# Altium schematic sheet 14871_Schematic.SchDoc(14871_Schematic) (continued)

## Library data of the parts
C1 C6 C7 C9 C10 = 08055C104KAT2A: CAP CER 0.1UF X7R 50V 0805  +/-10%; 50WVDC; SMD; 2.5% Df; 0.037IN. ,; Manufacturer: Kyocera AVX; Capacitance: 100nF; Dielectric Material: Ceramic; Insulation Resistance: 100GR; Tolerance: 10%; Case Code (Metric): 2012; Case/Package: 0805; Category: Ceramic Capacitors; Component Kind: Standard; ComponentLink1Description: https://datasheet.ciiva.com/19475/2009691-19475858.pdf?src-supplier=Jameco; ComponentLink1URL: https://datasheet.ciiva.com/19475/2009691-19475858.pdf?src-supplier=Jameco; ComponentLink2Description: https://datasheet.ciiva.com/11786/0900766b802d4698-11786116.pdf?src-supplier=RSComponents; ComponentLink2URL: https://datasheet.ciiva.com/11786/0900766b802d4698-11786116.pdf?src-supplier=RSComponents; ComponentLink3Description: https://datasheet.ciiva.com/2988/cx7r-2988014.pdf?src-supplier=Avnet; ComponentLink3URL: https://datasheet.ciiva.com/2988/cx7r-2988014.pdf?src-supplier=Avnet; ComponentLink4Description: https://datasheet.ciiva.com/16199/cx7r-16199014.pdf?src-supplier=Future+Electronics; ComponentLink4URL: https://datasheet.ciiva.com/16199/cx7r-16199014.pdf?src-supplier=Future+Electronics; ComponentLink5Description: https://datasheet.ciiva.com/11504/cx7r-11504044.pdf?src-supplier=Avnet; ComponentLink5URL: https://datasheet.ciiva.com/11504/cx7r-11504044.pdf?src-supplier=Avnet; ComponentLink6Description: https://datasheet.ciiva.com/150/cx7r-150258.pdf?src-supplier=Digi-Key; ComponentLink6URL: https://datasheet.ciiva.com/150/cx7r-150258.pdf?src-supplier=Digi-Key; ComponentLink7Description: https://datasheet.ciiva.com/150/cx7r-150257.pdf?src-supplier=Digi-Key; ComponentLink7URL: https://datasheet.ciiva.com/150/cx7r-150257.pdf?src-supplier=Digi-Key; ComponentLink8Description: https://datasheet.ciiva.com/150/cx7r-150259.pdf?src-supplier=Digi-Key; ComponentLink8URL: https://datasheet.ciiva.com/150/cx7r-150259.pdf?src-supplier=Digi-Key; ComponentLink9Description: https://datasheet.ciiva.com/7812/cx7r-7812079.pdf?src-supplier=Element14; ComponentLink9URL: https://datasheet.ciiva.com/7812/cx7r-7812079.pdf?src-supplier=Element14; ComponentLink10Description: https://datasheet.ciiva.com/5416/cx7r-5416959.pdf?src-supplier=Mouser; ComponentLink10URL: https://datasheet.ciiva.com/5416/cx7r-5416959.pdf?src-supplier=Mouser; Depth: 1.25mm; Dielectric: X7R; Dissipation Factor: 2.5%; Height: 0.5mm; Lead Free: Lead Free; Length: 2mm; Library Name: MAX14871_Project.SchLib; Manufacturer Part Number: 08055C104KAT2A; Max Operating Temperature: 125°C; Min Operating Temperature: -55°C; Mount: Surface Mount,PCB; Number of Pins: 2; Package Quantity: 4000; Packaging: Cut Tape; Pricing 1: 1=0.10000, 10=0.06700, 50=0.03640, 100=0.02970, 500=0.02120, 1000=0.01666 (USD); Radiation Hardening: No; Reach SVHC Compliant: Yes; RoHS: Yes; RoHS Compliant: Yes; Series: MLCC X7R; Stock 1: 12454485; Supplier 1: Digi-Key; Supplier Part Number 1: 478-1395-1-ND; Termination: Standard; Thickness: 0.037inch; Voltage Rating: 50V; Voltage Rating (DC): 50V; Weight: 0.001411oz; Width: 1.2mm
  C9: Voltage: 50V
C2 = GRM21BR61E106KA73L: CAP CER 10UF 25V X5R 0805; Manufacturer: Murata; Material: Ceramic; Tolerance: 10%; Capacitance: 10uF; Case Code (Metric): 2012; Case/Package: 0805; Category: Ceramic Capacitors; Component Kind: Standard; ComponentLink1Description: https://datasheet.ciiva.com/22774/1747469-22774396.pdf?src-supplier=Farnell; ComponentLink1URL: https://datasheet.ciiva.com/22774/1747469-22774396.pdf?src-supplier=Farnell; ComponentLink2Description: https://datasheet.ciiva.com/28584/626718-28584288.pdf?src-supplier=Element14; ComponentLink2URL: https://datasheet.ciiva.com/28584/626718-28584288.pdf?src-supplier=Element14; ComponentLink3Description: https://datasheet.ciiva.com/5424/product-5424327.pdf?src-supplier=Mouser; ComponentLink3URL: https://datasheet.ciiva.com/5424/product-5424327.pdf?src-supplier=Mouser; ComponentLink4Description: https://datasheet.ciiva.com/16440/grm21br61e106ka73l-16440627.pdf?src-supplier=Future+Electronics; ComponentLink4URL: https://datasheet.ciiva.com/16440/grm21br61e106ka73l-16440627.pdf?src-supplier=Future+Electronics; ComponentLink5Description: https://datasheet.ciiva.com/29199/454623-da-01-en-vs-kondensator-0805-29199615.pdf?src-supplier=Conrad; ComponentLink5URL: https://datasheet.ciiva.com/29199/454623-da-01-en-vs-kondensator-0805-29199615.pdf?src-supplier=Conrad; ComponentLink6Description: https://datasheet.ciiva.com/14116/grmseries-highdielectrictype-eng-14116376.pdf?src-supplier=Distrelec; ComponentLink6URL: https://datasheet.ciiva.com/14116/grmseries-highdielectrictype-eng-14116376.pdf?src-supplier=Distrelec; ComponentLink7Description: https://datasheet.ciiva.com/7719/1747469-7719093.pdf?src-supplier=Element14; ComponentLink7URL: https://datasheet.ciiva.com/7719/1747469-7719093.pdf?src-supplier=Element14; ComponentLink8Description: https://datasheet.ciiva.com/28584/626718-28584279.pdf?src-supplier=Element14; ComponentLink8URL: https://datasheet.ciiva.com/28584/626718-28584279.pdf?src-supplier=Element14; ComponentLink9Description: https://datasheet.ciiva.com/139/partnumbering-e-01-139183.pdf?src-supplier=Digi-Key; ComponentLink9URL: https://datasheet.ciiva.com/139/partnumbering-e-01-139183.pdf?src-supplier=Digi-Key; ComponentLink10Description: https://datasheet.ciiva.com/139/partnumbering-e-01-139182.pdf?src-supplier=Digi-Key; ComponentLink10URL: https://datasheet.ciiva.com/139/partnumbering-e-01-139182.pdf?src-supplier=Digi-Key; ComponentLink11Description: https://datasheet.ciiva.com/139/partnumbering-e-01-139184.pdf?src-supplier=Digi-Key; ComponentLink11URL: https://datasheet.ciiva.com/139/partnumbering-e-01-139184.pdf?src-supplier=Digi-Key; Construction: Flat; Depth: 1.25mm; Dielectric: X5R; Height: 1.25mm; Lead Free: Lead Free; Length: 2mm; Library Name: MAX14871_Project.SchLib; Manufacturer Part Number: GRM21BR61E106KA73L; Max Operating Temperature: 85°C; Min Operating Temperature: -55°C; Mount: Surface Mount; Package Quantity: 1; Packaging: Tape and Reel; Pricing 1: 1=0.25000, 10=0.17500, 50=0.11640, 100=0.09890, 500=0.06984, 1000=0.06111 (USD); Radiation Hardening: No; Reach SVHC Compliant: Yes; RoHS: Yes; RoHS Compliant: Yes; Series: GRM; Stock 1: 4346659; Supplier 1: Digi-Key; Supplier Part Number 1: 490-5523-1-ND; Termination: SMD/SMT; Thickness: 0.053inch; Voltage Rating: 25V; Voltage Rating (DC): 25V; Width: 0.049inch
C3 C4 = CL21A226MQQNNNG: CAP CER 6.3V X5R 20% SMD 0805 85°C Embossed T/R; Manufacturer: Samsung; Material: Ceramic; Capacitance: 22uF; Case Code (Metric): 2012; Case/Package: 0805; Category: Ceramic Capacitors; Component Kind: Standard; ComponentLink1Description: https://datasheet.ciiva.com/25728/cl21a226mqqnnng-25728680.pdf?src-supplier=Digi-Key; ComponentLink1URL: https://datasheet.ciiva.com/25728/cl21a226mqqnnng-25728680.pdf?src-supplier=Digi-Key; ComponentLink2Description: https://datasheet.ciiva.com/29199/454647-da-01-en-vs-kondensator-0805-29199620.pdf?src-supplier=Conrad; ComponentLink2URL: https://datasheet.ciiva.com/29199/454647-da-01-en-vs-kondensator-0805-29199620.pdf?src-supplier=Conrad; Construction: Flat; Depth: 1.25mm; Dielectric: X5R; Height: 1.25mm; Length: 2mm; Library Name: MAX14871_Project.SchLib; Manufacturer Part Number: CL21A226MQQNNNG; Max Operating Temperature: 85°C; Min Operating Temperature: -55°C; Mount: Surface Mount; Package Quantity: 3000; Packaging: Tape and Reel; Pricing 1: 1=0.13000, 10=0.09300, 50=0.05300, 100=0.04380, 500=0.03074, 1000=0.02545 (USD); Radiation Hardening: No; RoHS: Yes; RoHS Compliant: Yes; Series: CL; Stock 1: 1010294; Supplier 1: Digi-Key; Supplier Part Number 1: 1276-6460-1-ND; Thickness: 0.055inch; Tolerance: 20%; Voltage Rating: 6.3V; Width: 0.049inch
C5 C12 = 0805DC105KAT2A: Cap Cer 1UF 35V X7R 0805; Manufacturer: Kyocera AVX; Category: Ceramic Capacitors; Component Kind: Standard; ComponentLink1Description: http://www.mouser.com/ds/2/40/X7RDielectric-777024.pdf?src-supplier=Mouser; ComponentLink1URL: http://www.mouser.com/ds/2/40/X7RDielectric-777024.pdf?src-supplier=Mouser; Library Name: MAX14871_Project.SchLib; Manufacturer Part Number: 0805DC105KAT2A; Packaging: Tape and Reel; Pricing 1: 1=0.22000, 10=0.15100, 50=0.09040, 100=0.07640, 500=0.05226, 1000=0.04623 (USD); Rating: 35V; RoHS: Yes; Stock 1: 25687; Supplier 1: Digi-Key; Supplier Part Number 1: 478-0805DC105KAT2ACT-ND
C8 C11 = C0805C103K5RACTU: CAP CER 0.01uF 50V X7R 10% SMD 0805 125C Plastic T/R; Manufacturer: KEMET; Capacitance: 10nF; Case Code (Metric): 2012; Case/Package: 0805; Category: Ceramic Capacitors; Component Kind: Standard; ComponentLink1Description: http://www.farnell.com/datasheets/2740682.pdf?src-supplier=Farnell; ComponentLink1URL: http://www.farnell.com/datasheets/2740682.pdf?src-supplier=Farnell; ComponentLink2Description: http://www.onlinecomponents.com/productFiles/mf-KEM/kem_c1002_x7r_smd.pdf?src-supplier=Onlinecomponents.com; ComponentLink2URL: http://www.onlinecomponents.com/productFiles/mf-KEM/kem_c1002_x7r_smd.pdf?src-supplier=Onlinecomponents.com; ComponentLink3Description: https://www.verical.com/server-webapp/html/getDatasheet?partID=293414&src-supplier=Verical; ComponentLink3URL: https://www.verical.com/server-webapp/html/getDatasheet?partID=293414&src-supplier=Verical; ComponentLink4Description: http://www.farnell.com/datasheets/2740682.pdf?src-supplier=Newark; ComponentLink4URL: http://www.farnell.com/datasheets/2740682.pdf?src-supplier=Newark; ComponentLink5Description: http://www.farnell.com/datasheets/2740682.pdf?src-supplier=Element14; ComponentLink5URL: http://www.farnell.com/datasheets/2740682.pdf?src-supplier=Element14; ComponentLink6Description: https://api.kemet.com/component-edge/download/datasheet/C0805C103K5RACTU.pdf?src-supplier=Digi-Key; ComponentLink6URL: https://api.kemet.com/component-edge/download/datasheet/C0805C103K5RACTU.pdf?src-supplier=Digi-Key; ComponentLink7Description: http://www.kemet.com/Lists/ProductCatalog/Attachments/53/KEM_C1002_X7R_SMD.pdf?src-supplier=Avnet; ComponentLink7URL: http://www.kemet.com/Lists/ProductCatalog/Attachments/53/KEM_C1002_X7R_SMD.pdf?src-supplier=Avnet; Construction: Flat; Contact Plating: Tin,Matte; Depth: 1.25mm; Dielectric: X7R; Height: 0.78mm; Lead Pitch: 0.75mm; Length: 2mm; Library Name: MAX14871_Project.SchLib; Manufacturer Part Number: C0805C103K5RACTU; Max Operating Temperature: 125°C; Min Operating Temperature: -55°C; Mount: Surface Mount; Package Quantity: 4000; Packaging: Tape and Reel; Pricing 1: 1=0.11000, 10=0.07500, 50=0.04260, 100=0.03530, 500=0.02476, 1000=0.02050 (USD); Radiation Hardening: No; RoHS: Yes; Stock 1: 3238718; Supplier 1: Digi-Key; Supplier Part Number 1: 399-C0805C103K5RAC7800CT-ND; Termination: SMD/SMT; Tolerance: 10%; Voltage Rating: 50V; Voltage Rating (DC): 50V; Width: 1.2mm
D1 = BZT52C10-7-F: Vz=10V 9.4V~10.6V Izt=5mA; Manufacturer: Diodes; Element Configuration: Single; ESD Protection: No; Forward Voltage: 900mV; Impedance: 20R; Max Reverse Current: 200nA; Output Current: 50mA; Test Current: 5mA; Tolerance: 6%; Category: Zener Diodes; Component Kind: Standard; ComponentLink1Description: https://datasheet.ciiva.com/15142/getdatasheetpartid-89980-15142547.pdf?src-supplier=Verical; ComponentLink1URL: https://datasheet.ciiva.com/15142/getdatasheetpartid-89980-15142547.pdf?src-supplier=Verical; ComponentLink2Description: https://datasheet.ciiva.com/27052/1539497-27052374.pdf?src-supplier=Jameco; ComponentLink2URL: https://datasheet.ciiva.com/27052/1539497-27052374.pdf?src-supplier=Jameco; Contact Plating: Tin,Matte; Height: 1.35mm; Lead Free: Lead Free; Length: 2.85mm; Library Name: MAX14871_Project.SchLib; Manufacturer Part Number: BZT52C10-7-F; Max Operating Temperature: 150°C; Max Reverse Leakage Current: 200nA; Min Operating Temperature: -65°C; Mount: Surface Mount; Number of Pins: 2; Package Quantity: 3000; Packaging: Tape and Reel; Power Dissipation: 500mW; Power Rating: 500mW; Pricing 1: 1=0.22000, 10=0.19900, 100=0.10830, 500=0.06662, 1000=0.04542 (USD); Radiation Hardening: No; RoHS: Yes; RoHS Compliant: Yes; Series: -; Stock 1: 627176; Supplier 1: Digi-Key; Supplier Part Number 1: BZT52C10-FDICT-ND; Termination: SMD/SMT; Voltage Rating (DC): 10V; Voltage Tolerance: 6%; Weight: 0.000353oz; Width: 1.7mm; Working Voltage: 10V; Zener Current: 5mA; Zener Voltage: 10V
D2 = SMF16A-TP: TVS DIODE 16VWM 26VC SOD123FL; Manufacturer: MCC; Clamping Voltage: 26V; Diode Type: ZENER; Direction: Unidirectional; Element Configuration: Single; Leakage Current: 1uA; Max Breakdown Voltage: 19.7V; Min Breakdown Voltage: 17.8V; Number of Unidirectional Channels: 1; Peak Pulse Current: 7.7A; Peak Pulse Power: 200W; Power Line Protection: No; Clamping Voltage-Max: 26V; Component Kind: Standard; ComponentLink1Description: https://datasheet.ciiva.com/6574/smf5-0170sod-123fl-6574110.pdf?src-supplier=Digi-Key; ComponentLink1URL: https://datasheet.ciiva.com/6574/smf5-0170sod-123fl-6574110.pdf?src-supplier=Digi-Key; ComponentLink2Description: https://datasheet.ciiva.com/6574/smf5-0170sod-123fl-6574111.pdf?src-supplier=Digi-Key; ComponentLink2URL: https://datasheet.ciiva.com/6574/smf5-0170sod-123fl-6574111.pdf?src-supplier=Digi-Key; Depth: 1.8mm; Lead Free: Lead Free; Length: 2.85mm; Library Name: MAX14871_Project.SchLib; Manufacturer Part Number: SMF16A-TP; Max Operating Temperature: 175°C; Min Operating Temperature: -65°C; Mount: Surface Mount; Number of Pins: 2; Package Quantity: 1; Packaging: Tape and Reel; Power Dissipation: 200W; Pricing 1: 1=0.43000, 10=0.32600, 100=0.20330, 500=0.13910, 1000=0.10700 (USD); Radiation Hardening: No; Resistor Type: Zener; Reverse Standoff Voltage: 16V; RoHS: Yes; RoHS Compliant: Yes; Series: -; Stock 1: 30285; Supplier 1: Digi-Key; Supplier Part Number 1: SMF16A-TPMSCT-ND; Working Voltage: 16V
F1 = 0685T3000-01: Fuse Chip 3A 63V Slow Blow 2-Pin T/R; Manufacturer: Bel; Approvals: CE,cURus; DC Cold Resistance: 54mR; Fuse Type: Board Mount (Cartridge Style Excluded); Case Code (Metric): 3216; Case/Package: 1206; Category: Fuses; Component Kind: Standard; ComponentLink1Description: https://belfuse.com/resources/datasheets/circuitprotection/ds-cp-c1t-series.pdf?src-supplier=Digi-Key; ComponentLink1URL: https://belfuse.com/resources/datasheets/circuitprotection/ds-cp-c1t-series.pdf?src-supplier=Digi-Key; Current Rating: 3A; Library Name: MAX14871_Project.SchLib; Manufacturer Part Number: 0685T3000-01; Max Operating Temperature: 125°C; Min Operating Temperature: -55°C; Mount: Surface Mount; Packaging: Tape and Reel; Pricing 1: 1=0.30000, 5=0.29600, 10=0.28200, 50=0.25180, 100=0.23450, 250=0.20848, 500=0.17372, 1000=0.16503 (USD); RoHS: Yes; RoHS Compliant: Yes; Stock 1: 409603; Supplier 1: Digi-Key; Supplier Part Number 1: 507-1896-1-ND; Voltage Rating (AC): 63V; Voltage Rating (DC): 63V
FID1-FID4 = FID_1mm: Fiducial 40mil diameter, 120 Mask; Library Name: MAX14871_Project.SchLib
J1 J2 = 1935161: Conn PC Terminal Block 2 POS 5mm Solder ST Thru-Hole 17.5A; Manufacturer: Phoenix Contact; Approvals: UL; Connector Type: Screw; Current: 18A; Max Current Rating: 16A; Max Supply Voltage: 250V; Number of Circuits: 2; Number of Levels: 1; Voltage: 300V; Voltage Rating (DC): 320V; Wire Gauge (Max): 26AWG; Wire Gauge (Min): 12AWG; Category: Terminal Blocks; Color: Green; Component Kind: Standard; ComponentLink1Description: https://datasheet.ciiva.com/16047/1935161-16047247.pdf?src-supplier=Onlinecomponents.com; ComponentLink1URL: https://datasheet.ciiva.com/16047/1935161-16047247.pdf?src-supplier=Onlinecomponents.com; ComponentLink2Description: https://datasheet.ciiva.com/15169/getdatasheetpartid-177903-15169663.pdf?src-supplier=Verical; ComponentLink2URL: https://datasheet.ciiva.com/15169/getdatasheetpartid-177903-15169663.pdf?src-supplier=Verical; ComponentLink3Description: https://datasheet.ciiva.com/824/combiconcompact5-0mmseries-824222.pdf?src-supplier=Digi-Key; ComponentLink3URL: https://datasheet.ciiva.com/824/combiconcompact5-0mmseries-824222.pdf?src-supplier=Digi-Key; Contact Material: Copper,Tin; Current Rating: 17.5A; Depth: 9mm; Flammability Rating: UL94 V-0; Height: 11.3mm; Housing Color: Green; Housing Material: Polyamide; Insulation Material: Nylon; Lead Free: Lead Free; Length: 9mm; Library Name: MAX14871_Project.SchLib; Manufacturer Part Number: 1935161; Material: Nylon; Mount: Through Hole; Number of Contacts: 2; Number of Pins: 2; Number of Poles: 2; Number of Positions: 2; Number of Positions Per Level: 2; Number of Rows: 1; Number of Ways: 2; Orientation: Straight; Package Quantity: 250; Packaging: Bulk; Pitch: 5mm; Pricing 1: 1=0.54000, 10=0.47200, 50=0.45100, 100=0.43870, 250=0.41000, 500=0.37720, 1000=0.36550 (USD); Radiation Hardening: No; RoHS: Yes; RoHS Compliant: Yes; Series: COMBICON PT; Stock 1: 238571; Supplier 1: Digi-Key; Supplier Part Number 1: 277-1667-ND; Termination: Solder; Voltage Rating: 250V; Weight: 0.067021oz; Width: 14.9mm; Wire/Cable Gauge: 26AWG
JP1 = 68000-103HLF: CONN HEADER VERT 3POS 2.54MM; Component Kind: Standard; Library Name: MAX14871_Project.SchLib
L1 = NR6028T4R7M: Inductor Power Shielded Wirewound 4.7uH 20% 100KHz Ferrite 3A 0.0403Ohm DCR 2424 T/R; Manufacturer: Taiyo Yuden; DC Resistance (DCR): 40.3mR; Saturation Current: 2.7A; Self Resonant Frequency: 39MHz; Case/Package: 2424; Category: Fixed Inductors; Component Kind: Standard; ComponentLink1Description: https://datasheet.ciiva.com/7291/nr6028t4r7m-ss-7291638.pdf?src-supplier=Newark; ComponentLink1URL: https://datasheet.ciiva.com/7291/nr6028t4r7m-ss-7291638.pdf?src-supplier=Newark; ComponentLink2Description: https://datasheet.ciiva.com/8502/nr6028t4r7m-ss-8502688.pdf?src-supplier=Avnet; ComponentLink2URL: https://datasheet.ciiva.com/8502/nr6028t4r7m-ss-8502688.pdf?src-supplier=Avnet; ComponentLink3Description: https://datasheet.ciiva.com/15988/314nr6028t4r7m-15988117.pdf?src-supplier=Onlinecomponents.com; ComponentLink3URL: https://datasheet.ciiva.com/15988/314nr6028t4r7m-15988117.pdf?src-supplier=Onlinecomponents.com; ComponentLink4Description: https://datasheet.ciiva.com/1043/wound04-e-1043082.pdf?src-supplier=Digi-Key; ComponentLink4URL: https://datasheet.ciiva.com/1043/wound04-e-1043082.pdf?src-supplier=Digi-Key; ComponentLink5Description: https://datasheet.ciiva.com/1043/wound04-e-1043081.pdf?src-supplier=Digi-Key; ComponentLink5URL: https://datasheet.ciiva.com/1043/wound04-e-1043081.pdf?src-supplier=Digi-Key; ComponentLink6Description: https://datasheet.ciiva.com/1043/wound04-e-1043083.pdf?src-supplier=Digi-Key; ComponentLink6URL: https://datasheet.ciiva.com/1043/wound04-e-1043083.pdf?src-supplier=Digi-Key; ComponentLink7Description: https://datasheet.ciiva.com/4955/wound04-e-4955707.pdf?src-supplier=Mouser; ComponentLink7URL: https://datasheet.ciiva.com/4955/wound04-e-4955707.pdf?src-supplier=Mouser; Core Material: Ferrite; Depth: 6.2mm; Halogen Free: Halogen Free; Height: 2.8mm; Height - Seated (Max): 0.11inch; Inductance: 4.7uH; Inductance Tolerance: 20%; Lead Free: Lead Free; Length: 0.236inch; Library Name: MAX14871_Project.SchLib; Manufacturer Part Number: NR6028T4R7M; Max DC Current: 3A; Max Operating Temperature: 120°C; Min Operating Temperature: -25°C; Mount: Surface Mount; Number of Pins: 2; Package Quantity: 2000; Packaging: Tape and Reel; Pricing 1: 1=0.36000, 10=0.31900, 25=0.27920, 50=0.23940, 100=0.21940, 250=0.19948, 500=0.18750, 1000=0.14362 (USD); Radiation Hardening: No; Resistor Type: Wirewound; RMS Current (Irms): 3A; RoHS: Yes; RoHS Compliant: Yes; Series: NR; Shielding: Shielded; Stock 1: 261825; Supplier 1: Digi-Key; Supplier Part Number 1: 587-2100-1-ND; Termination: SMD/SMT; Test Frequency: 100kHz; Tolerance: 20%; Width: 0.236inch
LED1 = LGR971-K1-1: LED SMT GREEN 0805; Component Kind: Standard; Library Name: MAX14871_Project.SchLib; Supplier Part Number 1: 475-1410-1-ND
LED2 = LSR976-NR-1: LED SMT RED 0805; Manufacturer: Osram Opto; Dominant Wavelength: 633nm; Forward Current: 25mA; Forward Voltage: 2.5V; Lens Color: White; Lens Size: 1.25mm; Lens Style: Flat,Diffused,Colorless,Rectangular; Lens Transparency: Diffused; Luminous Flux: 0.47lm; Luminous Intensity: 180mcd; Number of Elements: 1; Power Dissipation: 65mW; Reverse Voltage: 12V; Test Current: 20mA; Viewing Angle: 160°; Case Code (Metric): 2012; Case/Package: 0805; Category: Uncategorized; Component Kind: Standard; ComponentLink1Description: https://datasheet.ciiva.com/4688/o-ls-ly-r976-24152-4688435.pdf?src-supplier=Mouser; ComponentLink1URL: https://datasheet.ciiva.com/4688/o-ls-ly-r976-24152-4688435.pdf?src-supplier=Mouser; ComponentLink2Description: https://datasheet.ciiva.com/26920/getdatasheetpartid-568367-26920831.pdf?src-supplier=Verical; ComponentLink2URL: https://datasheet.ciiva.com/26920/getdatasheetpartid-568367-26920831.pdf?src-supplier=Verical; ComponentLink3Description: https://datasheet.ciiva.com/2112/00078896-0-2112782.pdf?src-supplier=Digi-Key; ComponentLink3URL: https://datasheet.ciiva.com/2112/00078896-0-2112782.pdf?src-supplier=Digi-Key; ComponentLink4Description: https://datasheet.ciiva.com/2112/00078896-0-2112781.pdf?src-supplier=Digi-Key; ComponentLink4URL: https://datasheet.ciiva.com/2112/00078896-0-2112781.pdf?src-supplier=Digi-Key; ComponentLink5Description: https://datasheet.ciiva.com/2112/00078896-0-2112783.pdf?src-supplier=Digi-Key; ComponentLink5URL: https://datasheet.ciiva.com/2112/00078896-0-2112783.pdf?src-supplier=Digi-Key; Depth: 1.35mm; Height: 0.9mm; Illumination Color: Red; Length: 2.1mm; Library Name: MAX14871_Project.SchLib; Manufacturer Part Number: LSR976-NR-1; Max Operating Temperature: 85°C; Min Operating Temperature: -30°C; Mount: Surface Mount; Number of LEDs: 1; Number of Pins: 2; Package Quantity: 16000; Packaging: Tape and Reel; Pricing 1: 1=0.30000, 10=0.16400, 50=0.16400, 100=0.07700, 500=0.07100, 1000=0.05400, 5000=0.04700, 10000=0.04300, 16000=0.03700 (USD); Radiation Hardening: No; RoHS: Yes; RoHS Compliant: Yes; Series: CHIPLED 0805; Stock 1: 1195318; Supplier 1: Mouser; Supplier Part Number 1: 720-LSR976-NR-1; Width: 1.25mm
LINK1 = QPC02SXGN-RC: 2 Position 2.54 mm Centerline Single Circuit Open Top Jumper; Manufacturer: Sullins; Number of Positions: 2; Pitch: 0.1inch; Case/Package: 100; Category: Connectors; ComponentLink1Description: https://datasheet.ciiva.com/767/284-sxgn-11510-767869.pdf?src-supplier=Digi-Key; ComponentLink1URL: https://datasheet.ciiva.com/767/284-sxgn-11510-767869.pdf?src-supplier=Digi-Key; Contact Plating: Gold; Height: 0.235inch; Lead Free: Lead Free; Library Name: MAX14871_Project.SchLib; Manufacturer Part Number: QPC02SXGN-RC; Package Quantity: 2000; Packaging: Bulk; Pricing 1: 1=0.10000, 10=0.05000, 25=0.04080, 50=0.03780, 100=0.03650, 250=0.03152, 500=0.03024, 2000=0.02520, 4000=0.02394 (USD); RoHS: Yes; RoHS Compliant: Yes; Stock 1: 1015861; Supplier 1: Digi-Key; Supplier Part Number 1: S9337-ND
MH1-MH3 = MH_7.4MMC_3.2MMD: Mounting Hole 7.4mm Diameter, 3.2mm Drill; Library Name: MAX14871_Project.SchLib
MH4 = MH_7.4MMNC_3.2MMD: Hole 7.4MM Diameter (Non Copper), 3.2mm Drill NPTH; Library Name: MAX14871_Project.SchLib
Q1 = AOD413A: MOSFET P-CH 40V 12A TO252; Manufacturer: Alpha & Omega Semiconductor; Gate to Source Voltage (Vgs): 20V; Input Capacitance: 1.125nF; Max Power Dissipation: 50W; Number of Elements: 1; Rds On Max: 44mR; Case/Package: TO-252-3; Category: MOSFETs; Component Kind: Standard; ComponentLink1Description: https://datasheet.ciiva.com/6367/aod413a-6367556.pdf?src-supplier=Digi-Key; ComponentLink1URL: https://datasheet.ciiva.com/6367/aod413a-6367556.pdf?src-supplier=Digi-Key; ComponentLink2Description: https://datasheet.ciiva.com/6367/aod413a-6367558.pdf?src-supplier=Digi-Key; ComponentLink2URL: https://datasheet.ciiva.com/6367/aod413a-6367558.pdf?src-supplier=Digi-Key; ComponentLink3Description: https://datasheet.ciiva.com/6367/aod413a-6367560.pdf?src-supplier=Digi-Key; ComponentLink3URL: https://datasheet.ciiva.com/6367/aod413a-6367560.pdf?src-supplier=Digi-Key; Continuous Drain Current (ID): 12A; Drain to Source Voltage (Vdss): 40V; Lead Free: Lead Free; Library Name: MAX14871_Project.SchLib; Manufacturer Part Number: AOD413A; Max Operating Temperature: 175°C; Min Operating Temperature: -55°C; Mount: Surface Mount; Number of Pins: 3; Package Quantity: 2500; Packaging: Tape and Reel; Power Dissipation: 50W; Pricing 1: 1=0.61000, 10=0.54100, 100=0.41440, 500=0.32760, 1000=0.26208 (USD); RoHS: Yes; RoHS Compliant: Yes; Stock 1: 406428; Supplier 1: Digi-Key; Supplier Part Number 1: 785-1218-1-ND
Q2 = DMP21D0UT-7: MOSFET P-CH 20V 0.59A SOT523; Manufacturer: Diodes; Continuous Drain Current (ID): 650mA; Drain to Source Resistance: 495mR; Element Configuration: Single; Fall Time: 18.5ns; Gate to Source Voltage (Vgs): 8V; Input Capacitance: 80pF; Max Power Dissipation: 240mW; Number of Channels: 1; Polarity: P-CHANNEL; Rise Time: 8ns; Turn-Off Delay Time: 31.7ns; Turn-On Delay Time: 7.1ns; Case/Package: SOT-523; Category: MOSFETs; Component Kind: Standard; ComponentLink1Description: https://datasheet.ciiva.com/13055/dmp21d0ut-13055589.pdf?src-supplier=Mouser; ComponentLink1URL: https://datasheet.ciiva.com/13055/dmp21d0ut-13055589.pdf?src-supplier=Mouser; ComponentLink2Description: https://datasheet.ciiva.com/30480/0900766b8115c368-30480035.pdf?src-supplier=RSComponents; ComponentLink2URL: https://datasheet.ciiva.com/30480/0900766b8115c368-30480035.pdf?src-supplier=RSComponents; ComponentLink3Description: https://datasheet.ciiva.com/30023/dmp21d0ut-30023816.pdf?src-supplier=Avnet; ComponentLink3URL: https://datasheet.ciiva.com/30023/dmp21d0ut-30023816.pdf?src-supplier=Avnet; ComponentLink4Description: https://datasheet.ciiva.com/934/dmp21d0ut-934695.pdf?src-supplier=Digi-Key; ComponentLink4URL: https://datasheet.ciiva.com/934/dmp21d0ut-934695.pdf?src-supplier=Digi-Key; ComponentLink5Description: https://datasheet.ciiva.com/934/dmp21d0ut-934696.pdf?src-supplier=Digi-Key; ComponentLink5URL: https://datasheet.ciiva.com/934/dmp21d0ut-934696.pdf?src-supplier=Digi-Key; ComponentLink6Description: https://datasheet.ciiva.com/934/dmp21d0ut-934694.pdf?src-supplier=Digi-Key; ComponentLink6URL: https://datasheet.ciiva.com/934/dmp21d0ut-934694.pdf?src-supplier=Digi-Key; Drain to Source Voltage (Vdss): 20V; Height: 0.8mm; Lead Free: Lead Free; Length: 1.7mm; Library Name: MAX14871_Project.SchLib; Manufacturer Part Number: DMP21D0UT-7; Max Operating Temperature: 150°C; Min Operating Temperature: -55°C; Mount: Surface Mount; Number of Pins: 3; Package Quantity: 3000; Packaging: Tape and Reel; Pricing 1: 1=0.41000, 10=0.30900, 100=0.19230, 500=0.13156, 1000=0.10120 (USD); Radiation Hardening: No; Rds On Max: 495mR; Reach SVHC Compliant: Yes; RoHS: Yes; RoHS Compliant: Yes; Series: -; Stock 1: 2029106; Supplier 1: Digi-Key; Supplier Part Number 1: DMP21D0UT-7DICT-ND; Weight: 7.1E-05oz; Width: 0.85mm
R1 = RC0805FR-0752K3L: RES SMD 52K3 OHM 1% 1/8W 0805 ±100ppm/°C SMD Paper T/R; Manufacturer: Yageo; Max Power Dissipation: 125mW; Resistance: 52.3kR; Voltage Rating: 150V; Case Code (Metric): 2012; Case/Package: 0805; Category: Chip SMD Resistors; Component Kind: Standard; ComponentLink1Description: https://datasheet.ciiva.com/2457/pyu-r-int-thick-7-2457498.pdf?src-supplier=Digi-Key; ComponentLink1URL: https://datasheet.ciiva.com/2457/pyu-r-int-thick-7-2457498.pdf?src-supplier=Digi-Key; ComponentLink2Description: https://datasheet.ciiva.com/2457/pyu-r-int-thick-7-2457499.pdf?src-supplier=Digi-Key; ComponentLink2URL: https://datasheet.ciiva.com/2457/pyu-r-int-thick-7-2457499.pdf?src-supplier=Digi-Key; ComponentLink3Description: https://datasheet.ciiva.com/2457/pyu-r-int-thick-7-2457497.pdf?src-supplier=Digi-Key; ComponentLink3URL: https://datasheet.ciiva.com/2457/pyu-r-int-thick-7-2457497.pdf?src-supplier=Digi-Key; Construction: Rectangular; Contact Plating: Tin,Lead,Matte; Depth: 1.25mm; Features: Moisture Resistant; Height: 0.024inch; Lead Free: Lead Free; Length: 2mm; Library Name: MAX14871_Project.SchLib; Manufacturer Part Number: RC0805FR-0752K3L; Max Operating Temperature: 155°C; Military Standard: Not; Min Operating Temperature: -55°C; Number of Terminations: 2; Package Quantity: 1; Packaging: Tape and Reel; Power Rating: 125mW; Pricing 1: 1=0.10000, 10=0.03600, 100=0.01480, 1000=0.00666, 2500=0.00578 (USD); Radiation Hardening: No; Resistor Type: Thick Film; RoHS: Yes; RoHS Compliant: Yes; Series: RC; Size Code: 0805; Stock 1: 66100; Supplier 1: Digi-Key; Supplier Part Number 1: 311-52.3KCRCT-ND; Temperature Coefficient: 100ppm/°C; Termination: SMD/SMT; Voltage Rating (DC): 150V; Width: 1.25mm
R2 R4 R16 = RC0805FR-07200RL: RES SMD 200 OHM 1% 1/8W 0805 ±100ppm/°C; Manufacturer: Yageo; Max Power Dissipation: 125mW; Voltage Rating: 150V; Case Code (Metric): 2012; Case/Package: 0805; Category: Chip SMD Resistors; Component Kind: Standard; ComponentLink1Description: https://datasheet.ciiva.com/2519/pyu-r-int-thick-7-2519721.pdf?src-supplier=Digi-Key; ComponentLink1URL: https://datasheet.ciiva.com/2519/pyu-r-int-thick-7-2519721.pdf?src-supplier=Digi-Key; ComponentLink2Description: https://datasheet.ciiva.com/2519/pyu-r-int-thick-7-2519722.pdf?src-supplier=Digi-Key; ComponentLink2URL: https://datasheet.ciiva.com/2519/pyu-r-int-thick-7-2519722.pdf?src-supplier=Digi-Key; ComponentLink3Description: https://datasheet.ciiva.com/2519/pyu-r-int-thick-7-2519720.pdf?src-supplier=Digi-Key; ComponentLink3URL: https://datasheet.ciiva.com/2519/pyu-r-int-thick-7-2519720.pdf?src-supplier=Digi-Key; ComponentLink4Description: https://datasheet.ciiva.com/12667/rc0805-12667066.pdf?src-supplier=Mouser; ComponentLink4URL: https://datasheet.ciiva.com/12667/rc0805-12667066.pdf?src-supplier=Mouser; ComponentLink5Description: https://datasheet.ciiva.com/15189/getdatasheetpartid-327982-15189926.pdf?src-supplier=Verical; ComponentLink5URL: https://datasheet.ciiva.com/15189/getdatasheetpartid-327982-15189926.pdf?src-supplier=Verical; Construction: Rectangular; Depth: 1.25mm; Features: Moisture Resistant; Height: 0.024inch; Lead Free: Lead Free; Length: 2mm; Library Name: MAX14871_Project.SchLib; Manufacturer Part Number: RC0805FR-07200RL; Max Operating Temperature: 155°C; Military Standard: Not; Min Operating Temperature: -55°C; Mount: Surface Mount; Number of Terminations: 2; Package Quantity: 1; Packaging: Tape and Reel; Power Rating: 125mW; Pricing 1: 1=0.10000, 10=0.03600, 100=0.01480, 1000=0.00666, 2500=0.00578 (USD); Resistance: 200R; Resistor Type: Thick Film; RoHS: Yes; RoHS Compliant: Yes; Series: RC; Size Code: 0805; Stock 1: 939589; Supplier 1: Digi-Key; Supplier Part Number 1: 311-200CRCT-ND; Temperature Coefficient: 100ppm/°C; Termination: SMD/SMT; Voltage Rating (DC): 150V; Width: 0.049inch
R3 = RC0805FR-0710KL: RES SMD 10K OHM 1% 1/8W  0805 Thick Film+/-100ppm/C Epoxy Pad SMD T/R; Manufacturer: Yageo; Max Power Dissipation: 125mW; Resistance: 10kR; Temperature Coefficient: 100ppm/°C; Voltage Rating: 150V; Case Code (Metric): 2012; Case/Package: 0805; Category: Chip SMD Resistors; Component Kind: Standard; ComponentLink1Description: https://datasheet.ciiva.com/11808/0900766b8106beab-11808151.pdf?src-supplier=RSComponents; ComponentLink1URL: https://datasheet.ciiva.com/11808/0900766b8106beab-11808151.pdf?src-supplier=RSComponents; ComponentLink2Description: https://datasheet.ciiva.com/46447/444164-46447563.pdf?src-supplier=Element14; ComponentLink2URL: https://datasheet.ciiva.com/46447/444164-46447563.pdf?src-supplier=Element14; ComponentLink3Description: https://datasheet.ciiva.com/2456/pyu-r-int-thick-7-2456973.pdf?src-supplier=Digi-Key; ComponentLink3URL: https://datasheet.ciiva.com/2456/pyu-r-int-thick-7-2456973.pdf?src-supplier=Digi-Key; ComponentLink4Description: https://datasheet.ciiva.com/2456/pyu-r-int-thick-7-2456974.pdf?src-supplier=Digi-Key; ComponentLink4URL: https://datasheet.ciiva.com/2456/pyu-r-int-thick-7-2456974.pdf?src-supplier=Digi-Key; ComponentLink5Description: https://datasheet.ciiva.com/2456/pyu-r-int-thick-7-2456972.pdf?src-supplier=Digi-Key; ComponentLink5URL: https://datasheet.ciiva.com/2456/pyu-r-int-thick-7-2456972.pdf?src-supplier=Digi-Key; ComponentLink6Description: https://datasheet.ciiva.com/12669/rc0805-12669688.pdf?src-supplier=Mouser; ComponentLink6URL: https://datasheet.ciiva.com/12669/rc0805-12669688.pdf?src-supplier=Mouser; ComponentLink7Description: https://datasheet.ciiva.com/16747/rc0805-16747087.pdf?src-supplier=Future+Electronics; ComponentLink7URL: https://datasheet.ciiva.com/16747/rc0805-16747087.pdf?src-supplier=Future+Electronics; Construction: Rectangular; Depth: 1.25mm; Features: Moisture Resistant; Height: 0.024inch; Lead Free: Lead Free; Length: 0.079inch; Library Name: MAX14871_Project.SchLib; Manufacturer Part Number: RC0805FR-0710KL; Max Operating Temperature: 155°C; Military Standard: Not; Min Operating Temperature: -55°C; Number of Terminations: 2; Package Quantity: 1; Packaging: Tape and Reel; Power Rating: 125mW; Pricing 1: 1=0.10000, 10=0.03700, 100=0.01490, 1000=0.00669, 2500=0.00581 (USD); Resistor Type: Thick Film; RoHS: Yes; RoHS Compliant: Yes; Series: RC; Size Code: 0805; Stock 1: 11341268; Supplier 1: Digi-Key; Supplier Part Number 1: 311-10.0KCRCT-ND; Technology: THICK FILM; Termination: SMD/SMT; Tolerance: 1%; Width: 0.049inch
R5 = RMCF2010ZT0R00: Res Thick Film 2010 0 Ohm Molded SMD Automotive Plastic T/R; Manufacturer: Stackpole Electronics; Max Power Dissipation: 750mW; Case Code (Metric): 5025; Case/Package: 2010; Category: Chip SMD Resistors; Component Kind: Standard; ComponentLink1Description: http://components.arrow.com/part/dataview/55788137S308674N1174?src-supplier=Arrow+Electronics; ComponentLink1URL: http://components.arrow.com/part/dataview/55788137S308674N1174?src-supplier=Arrow+Electronics; ComponentLink2Description: https://www.seielect.com/catalog/SEI-Packaging.pdf?src-supplier=Digi-Key; ComponentLink2URL: https://www.seielect.com/catalog/SEI-Packaging.pdf?src-supplier=Digi-Key; ComponentLink3Description: https://www.seielect.com/Catalog/SEI-RMCF_RMCP.pdf?src-supplier=Digi-Key; ComponentLink3URL: https://www.seielect.com/Catalog/SEI-RMCF_RMCP.pdf?src-supplier=Digi-Key; ComponentLink4Description: https://www.seielect.com/catalog/SEI-RMCF_RMCP.pdf?src-supplier=Avnet; ComponentLink4URL: https://www.seielect.com/catalog/SEI-RMCF_RMCP.pdf?src-supplier=Avnet; ComponentLink5Description: http://www.seielect.com/Catalog/SEI-RMC.pdf?src-supplier=Future+Electronics; ComponentLink5URL: http://www.seielect.com/Catalog/SEI-RMC.pdf?src-supplier=Future+Electronics; ComponentLink6Description: https://www.verical.com/server-webapp/html/getDatasheet?partID=691632&src-supplier=Verical; ComponentLink6URL: https://www.verical.com/server-webapp/html/getDatasheet?partID=691632&src-supplier=Verical; Construction: Rectangular; Contact Plating: Tin,Matte; Depth: 2.5mm; Features: Automotive AEC-Q200; Height: 0.028inch; Lead Free: Lead Free; Length: 0.197inch; Library Name: MAX14871_Project.SchLib; Manufacturer Part Number: RMCF2010ZT0R00; Max Operating Temperature: 155°C; Military Standard: Not; Min Operating Temperature: -55°C; Mount: Surface Mount; Number of Terminations: 2; Packaging: Tape and Reel; Power Rating: 750mW; Pricing 1: 1=0.20000, 10=0.16700, 25=0.12120, 50=0.08780, 100=0.06510, 250=0.05000, 500=0.03940, 1000=0.02727 (USD); Radiation Hardening: No; Resistance: 0R; Resistor Type: Thick Film; RoHS: Yes; RoHS Compliant: Yes; Series: RMCF; Size Code: 2010; Stock 1: 436684; Supplier 1: Digi-Key; Supplier Part Number 1: RMCF2010ZT0R00CT-ND; Width: 0.098inch
R6 R8 R9 R13 R15 R19 R20 R23 = RC0805FR-071KL: RES SMD 1K OHM 1% 1/8W 0805; Manufacturer: Yageo; Max Power Dissipation: 125mW; Resistance: 1kR; Temperature Coefficient: 100ppm/°C; Voltage Rating: 150V; Case Code (Metric): 2012; Case/Package: 0805; Category: Chip SMD Resistors; Component Kind: Standard; ComponentLink1Description: https://datasheet.ciiva.com/7941/1686128-7941719.pdf?src-supplier=Element14; ComponentLink1URL: https://datasheet.ciiva.com/7941/1686128-7941719.pdf?src-supplier=Element14; ComponentLink2Description: https://datasheet.ciiva.com/46385/444164-46385020.pdf?src-supplier=Element14; ComponentLink2URL: https://datasheet.ciiva.com/46385/444164-46385020.pdf?src-supplier=Element14; ComponentLink3Description: https://datasheet.ciiva.com/2456/pyu-r-int-thick-7-2456976.pdf?src-supplier=Digi-Key; ComponentLink3URL: https://datasheet.ciiva.com/2456/pyu-r-int-thick-7-2456976.pdf?src-supplier=Digi-Key; ComponentLink4Description: https://datasheet.ciiva.com/2456/pyu-r-int-thick-7-2456977.pdf?src-supplier=Digi-Key; ComponentLink4URL: https://datasheet.ciiva.com/2456/pyu-r-int-thick-7-2456977.pdf?src-supplier=Digi-Key; ComponentLink5Description: https://datasheet.ciiva.com/2456/pyu-r-int-thick-7-2456975.pdf?src-supplier=Digi-Key; ComponentLink5URL: https://datasheet.ciiva.com/2456/pyu-r-int-thick-7-2456975.pdf?src-supplier=Digi-Key; ComponentLink6Description: https://datasheet.ciiva.com/16850/rc0805-16850409.pdf?src-supplier=Future+Electronics; ComponentLink6URL: https://datasheet.ciiva.com/16850/rc0805-16850409.pdf?src-supplier=Future+Electronics; Construction: Rectangular; Depth: 1.25mm; Features: Moisture Resistant; Height: 0.024inch; Lead Free: Lead Free; Length: 0.079inch; Library Name: MAX14871_Project.SchLib; Manufacturer Part Number: RC0805FR-071KL; Max Operating Temperature: 155°C; Military Standard: Not; Min Operating Temperature: -55°C; Number of Pins: 2; Number of Terminations: 2; Package Quantity: 1; Packaging: Tape and Reel; Power Rating: 125mW; Pricing 1: 1=0.10000, 10=0.03600, 100=0.01480, 1000=0.00666, 2500=0.00578 (USD); Reach SVHC Compliant: Yes; Resistor Type: Thick Film; RoHS: Yes; RoHS Compliant: Yes; Series: RC; Size Code: 0805; Stock 1: 9084773; Supplier 1: Digi-Key; Supplier Part Number 1: 311-1.00KCRCT-ND; Termination: SMD/SMT; Tolerance: 1%; Voltage Rating (DC): 150V; Width: 0.049inch
R7 = RC0805FR-077K15L: RES SMD 7K15 OHM 1% 1/8W 0805 Thick Film 2.0 x 1.2 mm 100ppm/ C Molded SMD Paper T/R; Manufacturer: Yageo; Max Power Dissipation: 125mW; Resistance: 7.15kR; Temperature Coefficient: 100ppm/°C; Voltage Rating: 150V; Case Code (Metric): 2012; Case/Package: 0805; Category: Chip SMD Resistors; Component Kind: Standard; ComponentLink1Description: https://datasheet.ciiva.com/2509/pyu-r-int-thick-7-2509532.pdf?src-supplier=Digi-Key; ComponentLink1URL: https://datasheet.ciiva.com/2509/pyu-r-int-thick-7-2509532.pdf?src-supplier=Digi-Key; ComponentLink2Description: https://datasheet.ciiva.com/2509/pyu-r-int-thick-7-2509533.pdf?src-supplier=Digi-Key; ComponentLink2URL: https://datasheet.ciiva.com/2509/pyu-r-int-thick-7-2509533.pdf?src-supplier=Digi-Key; ComponentLink3Description: https://datasheet.ciiva.com/2509/pyu-r-int-thick-7-2509531.pdf?src-supplier=Digi-Key; ComponentLink3URL: https://datasheet.ciiva.com/2509/pyu-r-int-thick-7-2509531.pdf?src-supplier=Digi-Key; ComponentLink4Description: https://datasheet.ciiva.com/5561/rc0805-5561850.pdf?src-supplier=Mouser; ComponentLink4URL: https://datasheet.ciiva.com/5561/rc0805-5561850.pdf?src-supplier=Mouser; ComponentLink5Description: https://datasheet.ciiva.com/17053/rc0805-17053637.pdf?src-supplier=Future+Electronics; ComponentLink5URL: https://datasheet.ciiva.com/17053/rc0805-17053637.pdf?src-supplier=Future+Electronics; Construction: Rectangular; Contact Plating: Tin,Matte,Lead; Depth: 1.25mm; Features: Moisture Resistant; Height: 0.024inch; Lead Free: Lead Free; Length: 2mm; Library Name: MAX14871_Project.SchLib; Manufacturer Part Number: RC0805FR-077K15L; Max Operating Temperature: 155°C; Military Standard: Not; Min Operating Temperature: -55°C; Number of Terminations: 2; Package Quantity: 1; Packaging: Tape and Reel; Power Rating: 125mW; Pricing 1: 1=0.10000, 10=0.03600, 100=0.01480, 1000=0.00666, 2500=0.00578 (USD); Radiation Hardening: No; Resistor Type: Thick Film; RoHS: Yes; RoHS Compliant: Yes; Series: RC; Size Code: 0805; Stock 1: 115604; Supplier 1: Digi-Key; Supplier Part Number 1: 311-7.15KCRCT-ND; Termination: SMD/SMT; Voltage Rating (DC): 150V; Weight: 0.000194oz; Width: 1.25mm
R10 R11 R21 = RC0805JR-070RL: JUMPER SMD 0 OHM 150V 1/8W 0805; Manufacturer: Yageo; Resistance: 0mR; Resistance Tolerance: 5%; Temperature Coefficient: 200ppm/°C; Case Code (Metric): 2012; Case/Package: 0805; Category: Chip SMD Resistors; Component Kind: Standard; ComponentLink1Description: https://datasheet.ciiva.com/11819/0900766b8106beab-11819430.pdf?src-supplier=RSComponents; ComponentLink1URL: https://datasheet.ciiva.com/11819/0900766b8106beab-11819430.pdf?src-supplier=RSComponents; ComponentLink2Description: https://datasheet.ciiva.com/7941/1738694-7941861.pdf?src-supplier=Element14; ComponentLink2URL: https://datasheet.ciiva.com/7941/1738694-7941861.pdf?src-supplier=Element14; ComponentLink3Description: https://datasheet.ciiva.com/7941/1738694-7941862.pdf?src-supplier=Element14; ComponentLink3URL: https://datasheet.ciiva.com/7941/1738694-7941862.pdf?src-supplier=Element14; ComponentLink4Description: https://datasheet.ciiva.com/7941/1738694-7941863.pdf?src-supplier=Element14; ComponentLink4URL: https://datasheet.ciiva.com/7941/1738694-7941863.pdf?src-supplier=Element14; ComponentLink5Description: https://datasheet.ciiva.com/15190/getdatasheetpartid-330685-15190028.pdf?src-supplier=Verical; ComponentLink5URL: https://datasheet.ciiva.com/15190/getdatasheetpartid-330685-15190028.pdf?src-supplier=Verical; Construction: Rectangular; Contact Plating: Tin,Matte; Depth: 1.25mm; Features: Moisture Resistant; Height: 0.5mm; Lead Free: Lead Free; Length: 2mm; Library Name: MAX14871_Project.SchLib; Manufacturer Part Number: RC0805JR-070RL; Max Operating Temperature: 155°C; Military Standard: Not; Min Operating Temperature: -55°C; Number of Pins: 2; Number of Terminations: 2; Packaging: Tape and Reel; Power Rating: 125mW; Pricing 1: 1=0.10000, 10=0.03100, 25=0.02280, 50=0.01720, 100=0.01280, 250=0.00972, 500=0.00778, 1000=0.00573 (USD); Radiation Hardening: No; Resistor Type: Thick Film; RoHS: Yes; RoHS Compliant: Yes; Series: RC; Size Code: 0805; Stock 1: 5377250; Supplier 1: Digi-Key; Supplier Part Number 1: 311-0.0ARCT-ND; Technology: THICK FILM; Termination: SMD/SMT; Tolerance: 5%; Voltage Rating: 150V; Voltage Rating (DC): 150V; Width: 1.25mm
R12 = PT01-D120D-B502: POT 5K OHM Panel Mount/Through Hole, Rotary Potentiometer; Manufacturer: CUI Devices; Component Kind: Standard; ComponentLink1Description: https://datasheet.octopart.com/PT01-D120D-B502-CUI-Devices-datasheet-158883457.pdf?src-supplier=CUI+Devices; ComponentLink1URL: https://datasheet.octopart.com/PT01-D120D-B502-CUI-Devices-datasheet-158883457.pdf?src-supplier=CUI+Devices; Library Name: MAX14871_Project.SchLib; Manufacturer Part Number: PT01-D120D-B502; Pricing 1: 1=0.79000, 10=0.66600, 25=0.61720, 50=0.58200, 100=0.54700, 250=0.47200, 1000=0.44100, 2500=0.41800 (USD); Stock 1: 984; Supplier 1: DigiKey; Supplier Part Number 1: 2223-PT01-D120D-B502-ND
R14 = RC0805JR-075K6L: RES SMD 5K6 OHM 5% 1/8W 0805  Thick Film ±100ppm/C Pad SMD T/R; Manufacturer: Yageo; Max Power Dissipation: 125mW; Resistance: 5.6kR; Temperature Coefficient: 100ppm/°C; Voltage Rating: 150V; Case Code (Metric): 2012; Case/Package: 0805; Category: Chip SMD Resistors; Component Kind: Standard; ComponentLink1Description: https://datasheet.ciiva.com/2519/pyu-r-int-thick-7-2519283.pdf?src-supplier=Digi-Key; ComponentLink1URL: https://datasheet.ciiva.com/2519/pyu-r-int-thick-7-2519283.pdf?src-supplier=Digi-Key; ComponentLink2Description: https://datasheet.ciiva.com/2519/pyu-r-int-thick-7-2519284.pdf?src-supplier=Digi-Key; ComponentLink2URL: https://datasheet.ciiva.com/2519/pyu-r-int-thick-7-2519284.pdf?src-supplier=Digi-Key; ComponentLink3Description: https://datasheet.ciiva.com/2519/pyu-r-int-thick-7-2519282.pdf?src-supplier=Digi-Key; ComponentLink3URL: https://datasheet.ciiva.com/2519/pyu-r-int-thick-7-2519282.pdf?src-supplier=Digi-Key; ComponentLink4Description: https://datasheet.ciiva.com/15190/getdatasheetpartid-348583-15190060.pdf?src-supplier=Verical; ComponentLink4URL: https://datasheet.ciiva.com/15190/getdatasheetpartid-348583-15190060.pdf?src-supplier=Verical; ComponentLink5Description: https://datasheet.ciiva.com/17086/rc0805-17086095.pdf?src-supplier=Future+Electronics; ComponentLink5URL: https://datasheet.ciiva.com/17086/rc0805-17086095.pdf?src-supplier=Future+Electronics; Construction: Rectangular; Contact Plating: Tin,Lead; Depth: 1.25mm; Features: Moisture Resistant; Height: 0.5mm; Lead Free: Lead Free; Length: 2mm; Library Name: MAX14871_Project.SchLib; Manufacturer Part Number: RC0805JR-075K6L; Max Operating Temperature: 155°C; Military Standard: Not; Min Operating Temperature: -55°C; Number of Terminations: 2; Package Quantity: 5000; Packaging: Tape and Reel; Power Rating: 125mW; Pricing 1: 1=0.100000, 10=0.032000, 100=0.013000, 1000=0.005840, 2500=0.005070 (USD); Radiation Hardening: No; Resistor Type: Thick Film; RoHS: Yes; RoHS Compliant: Yes; Series: RC; Size Code: 0805; Stock 1: 166799; Supplier 1: Digi-Key; Supplier Part Number 1: 311-5.6KARCT-ND; Termination: SMD/SMT; Tolerance: 5%; Width: 1.25mm
R17 = RC0805FR-074K02L: RES SMD 4K02 OHM 1% 1/8W 0805 Thick Film 2.0 x 1.2 mm 100ppm/ C Molded SMD Paper T/R; Manufacturer: Yageo; Max Power Dissipation: 125mW; Resistance: 4.02kR; Temperature Coefficient: 100ppm/°C; Voltage Rating: 150V; Case Code (Metric): 2012; Case/Package: 0805; Category: Chip SMD Resistors; Component Kind: Standard; ComponentLink1Description: https://datasheet.ciiva.com/11808/0900766b8106beab-11808579.pdf?src-supplier=RSComponents; ComponentLink1URL: https://datasheet.ciiva.com/11808/0900766b8106beab-11808579.pdf?src-supplier=RSComponents; ComponentLink2Description: https://datasheet.ciiva.com/12667/rc0805-12667015.pdf?src-supplier=Mouser; ComponentLink2URL: https://datasheet.ciiva.com/12667/rc0805-12667015.pdf?src-supplier=Mouser; ComponentLink3Description: https://datasheet.ciiva.com/15189/getdatasheetpartid-342839-15189978.pdf?src-supplier=Verical; ComponentLink3URL: https://datasheet.ciiva.com/15189/getdatasheetpartid-342839-15189978.pdf?src-supplier=Verical; ComponentLink4Description: https://datasheet.ciiva.com/16771/rc0805-16771996.pdf?src-supplier=Future+Electronics; ComponentLink4URL: https://datasheet.ciiva.com/16771/rc0805-16771996.pdf?src-supplier=Future+Electronics; Construction: Rectangular; Depth: 1.25mm; Features: Moisture Resistant; Height: 0.5mm; Lead Free: Lead Free; Length: 2mm; Library Name: MAX14871_Project.SchLib; Manufacturer Part Number: RC0805FR-074K02L; Max Operating Temperature: 155°C; Military Standard: Not; Min Operating Temperature: -55°C; Mount: Surface Mount; Number of Terminations: 2; Packaging: Tape and Reel; Power Rating: 125mW; Pricing 1: 1=0.10000, 10=0.03600, 100=0.01480, 1000=0.00666, 2500=0.00578 (USD); Resistor Type: Thick Film; RoHS: Yes; RoHS Compliant: Yes; Series: RC; Size Code: 0805; Stock 1: 404687; Supplier 1: Digi-Key; Supplier Part Number 1: 311-4.02KCRCT-ND; Technology: THICK FILM; Termination: SMD/SMT; Voltage Rating (DC): 150V
R18 = VSRP1206S1-R100F: RES SENSE SMD 0R1 OHM 1% 1W 1206; Manufacturer: Cyntec; Category: Chip SMD Resistors; Component Kind: Standard; Library Name: MAX14871_Project.SchLib; Manufacturer Part Number: VSRP1206S1-R100F; Pricing 1: 1=0.57000, 10=0.47700, 25=0.40920, 50=0.36820, 100=0.32040, 250=0.28632, 500=0.23180, 1000=0.18748 (USD); RoHS: Yes; Stock 1: 23192; Supplier 1: Digi-Key; Supplier Part Number 1: 2037-VSRP1206S1-R100FCT-ND
R22 = RC0805FR-073KL: RES SMD 3K OHM 1% 1/8W 0805 Thick Film ±100ppm/C Pad SMD T/R; Manufacturer: Yageo; Max Power Dissipation: 125mW; Resistance: 3kR; Temperature Coefficient: 100ppm/°C; Voltage Rating: 150V; Case Code (Metric): 2012; Case/Package: 0805; Category: Chip SMD Resistors; Component Kind: Standard; ComponentLink1Description: https://datasheet.ciiva.com/2457/pyu-r-int-thick-7-2457135.pdf?src-supplier=Digi-Key; ComponentLink1URL: https://datasheet.ciiva.com/2457/pyu-r-int-thick-7-2457135.pdf?src-supplier=Digi-Key; ComponentLink2Description: https://datasheet.ciiva.com/2457/pyu-r-int-thick-7-2457136.pdf?src-supplier=Digi-Key; ComponentLink2URL: https://datasheet.ciiva.com/2457/pyu-r-int-thick-7-2457136.pdf?src-supplier=Digi-Key; ComponentLink3Description: https://datasheet.ciiva.com/2457/pyu-r-int-thick-7-2457134.pdf?src-supplier=Digi-Key; ComponentLink3URL: https://datasheet.ciiva.com/2457/pyu-r-int-thick-7-2457134.pdf?src-supplier=Digi-Key; ComponentLink4Description: https://datasheet.ciiva.com/5558/rc0805-5558124.pdf?src-supplier=Mouser; ComponentLink4URL: https://datasheet.ciiva.com/5558/rc0805-5558124.pdf?src-supplier=Mouser; ComponentLink5Description: https://datasheet.ciiva.com/17043/rc0805-17043750.pdf?src-supplier=Future+Electronics; ComponentLink5URL: https://datasheet.ciiva.com/17043/rc0805-17043750.pdf?src-supplier=Future+Electronics; Construction: Rectangular; Depth: 1.25mm; Features: Moisture Resistant; Height: 0.024inch; Lead Free: Lead Free; Length: 2mm; Library Name: MAX14871_Project.SchLib; Manufacturer Part Number: RC0805FR-073KL; Max Operating Temperature: 155°C; Military Standard: Not; Min Operating Temperature: -55°C; Mount: Surface Mount; Number of Terminations: 2; Package Quantity: 1; Packaging: Tape and Reel; Power Rating: 125mW; Pricing 1: 1=0.10000, 10=0.03600, 100=0.01480, 1000=0.00666, 2500=0.00578 (USD); Resistor Type: Thick Film; RoHS: Yes; RoHS Compliant: Yes; Series: RC; Size Code: 0805; Stock 1: 518249; Supplier 1: Digi-Key; Supplier Part Number 1: 311-3.00KCRCT-ND; Termination: SMD/SMT; Voltage Rating (DC): 150V; Width: 0.049inch
U1 = AP62200WU-7: 4.2V to 18V Input 2A Low IQ Synchronous Buck Converter 6-Pin TSOT-26 T/R; Manufacturer: Diodes; Category: Voltage Regulators - Switching; Component Kind: Standard; Library Name: MAX14871_Project.SchLib; Manufacturer Part Number: AP62200WU-7; Pricing 1: 1=0.43000, 10=0.37100, 25=0.34600, 100=0.27690, 250=0.25712, 500=0.21758, 1000=0.16813 (USD); RoHS: Yes; RoHS Compliant: Yes; Stock 1: 508009; Supplier 1: Digi-Key; Supplier Part Number 1: 31-AP62200WU-7CT-ND
U2 = MAX14871EUE+T: IC Motor Driver 4.5V-36V 16TSSOP; Manufacturer: Analog Devices; Category: Uncategorized; Component Kind: Standard; Library Name: MAX14871_Project.SchLib; Manufacturer Part Number: MAX14871EUE+T; Pricing 1: 1=5.19000, 10=4.66500, 25=4.41000, 100=3.82200, 250=3.62600, 500=3.25360, 1000=2.94000 (USD); RoHS: No; Stock 1: 2369; Supplier 1: Digi-Key; Supplier Part Number 1: MAX14871EUE+CT-ND
U3 U4 = NE555DR: IC OSC SGL TIMER 100KHZ 8-SOIC; Manufacturer: Texas Instruments; High Level Output Current: -200mA; Low Level Output Current: 200mA; Max Supply Voltage: 16V; Min Supply Voltage: 4.5V; Number of Timers/Counters: 1; Operating Supply Current: 10mA; Quiescent Current: 15mA; Case/Package: SOIC; Category: Timers and Oscillators; Component Kind: Standard; ComponentLink1Description: https://datasheet.ciiva.com/46395/1874740-46395978.pdf?src-supplier=Element14; ComponentLink1URL: https://datasheet.ciiva.com/46395/1874740-46395978.pdf?src-supplier=Element14; ComponentLink2Description: https://datasheet.ciiva.com/1233/ne555-1233132.pdf?src-supplier=Digi-Key; ComponentLink2URL: https://datasheet.ciiva.com/1233/ne555-1233132.pdf?src-supplier=Digi-Key; ComponentLink3Description: https://datasheet.ciiva.com/1233/ne555-1233131.pdf?src-supplier=Digi-Key; ComponentLink3URL: https://datasheet.ciiva.com/1233/ne555-1233131.pdf?src-supplier=Digi-Key; ComponentLink4Description: https://datasheet.ciiva.com/1233/ne555-1233133.pdf?src-supplier=Digi-Key; ComponentLink4URL: https://datasheet.ciiva.com/1233/ne555-1233133.pdf?src-supplier=Digi-Key; ComponentLink5Description: https://datasheet.ciiva.com/1139/1874740-1139901.pdf?src-supplier=Element14; ComponentLink5URL: https://datasheet.ciiva.com/1139/1874740-1139901.pdf?src-supplier=Element14; Contact Plating: Gold; Frequency: 100kHz; Height: 1.58mm; Lead Free: Lead Free; Length: 4.9mm; Library Name: MAX14871_Project.SchLib; Manufacturer Part Number: NE555DR; Max Operating Temperature: 70°C; Min Operating Temperature: 0°C; Mount: Surface Mount; Number of Pins: 8; Package Quantity: 1; Packaging: Tape and Reel; Pricing 1: 1=0.46000, 10=0.34300, 25=0.30880, 100=0.21350, 250=0.17976, 500=0.14606, 1000=0.11236 (USD); Radiation Hardening: No; Reach SVHC Compliant: Yes; RoHS: Yes; RoHS Compliant: Yes; Series: -; Stock 1: 369283; Supplier 1: Digi-Key; Supplier Part Number 1: 296-6501-1-ND; Weight: 0.002561oz; Width: 3.91mm
